# Altium schematic sheet Soft_Start.SchDoc
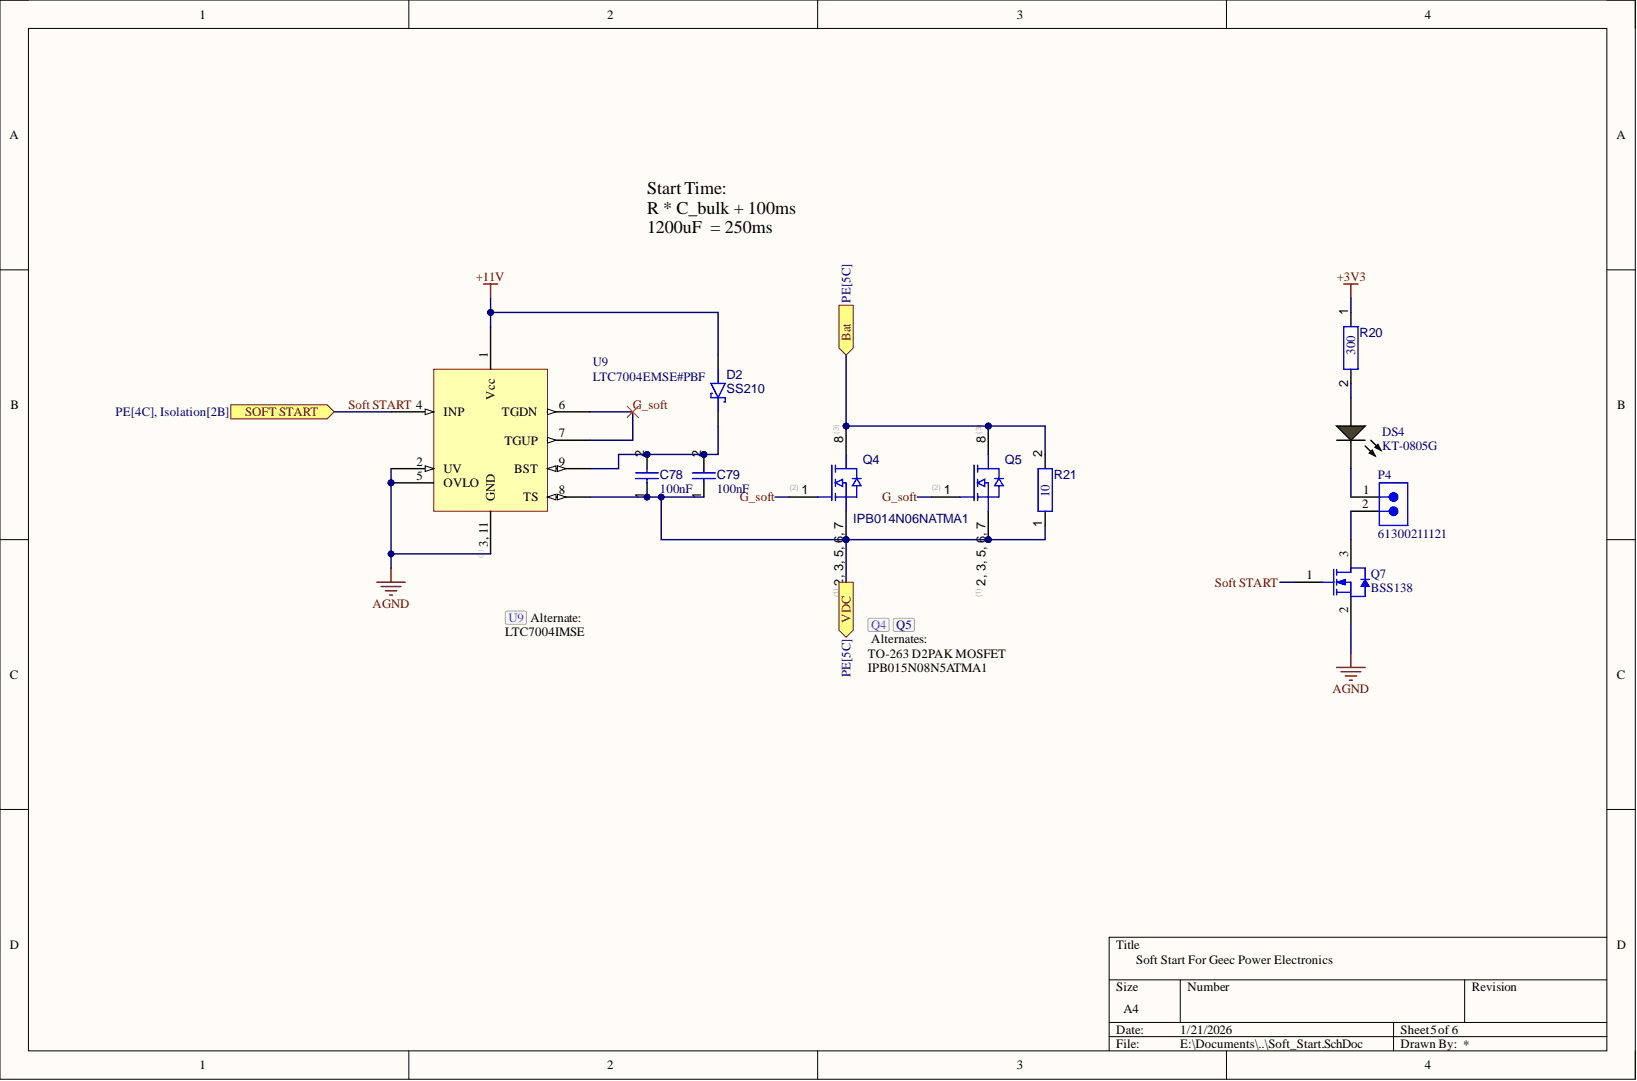
[diagram p0: Soft_Start.SchDoc]

## Parts
C78  value 100nF  [CAPC3216X06N]  (hidden comment: C1206C104K1RACTU)
C79  value 100nF  [CAPC3216X06N]  (hidden comment: C1206C104K1RACTU)
D2  SS210  [DIOM4226X24N]
DS4  KT-0805G  [WL-SMCW_0805_150080xx75000]
P4  61300211121  [61300211121]
Q4  IPB014N06NATMA1  [INF-PG-TO263-7-1_M]
Q5  IPB014N06NATMA1  [INF-PG-TO263-7-1_M]
Q7  BSS138  [FAIR-SOT23-3_V]
R20  value 300  [RESC3216X89X51NL25T25]  (hidden comment: 1206W4F3000T5E)
R21  value 10  [1623730-2-Footprint-1]  (hidden comment: 1623730-2)
U9  LTC7004EMSE#PBF  [TSSOP50P490X110_HS-11N]

## Ports
Bat, SOFT START, VDC

## Nets
+3V3: R20.1
+11V: D2.2 U9.1(Vcc)
AGND: Q7.2 U9.2(UV) U9.3(GND) U9.5(OVLO)
Bat: Q4.3 Q5.3 R21.2 ; port Bat
G_soft: Q4.2 Q5.2 U9.6(TGDN) U9.7(TGUP)
Soft START: Q7.1 U9.4(INP) ; port SOFT START
VDC: C78.1 C79.1 Q4.1 Q5.1 R21.1 U9.8(TS) ; port VDC
NetC78_2: C78.2 C79.2 D2.1 U9.9(BST)
NetDS4_1: DS4.1 R20.2
NetDS4_2: DS4.2 P4.1
NetP4_2: P4.2 Q7.3

## Library data of the parts
C78 C79 = CMP-001-00083-1: Ceramic Capacitor, Multilayer, Ceramic, 200V, 10% +Tol, 10% -Tol, X7R, 15% TC, 0.01uF, 1206; Voltage Rating: 100V; Case/Package: 1206; Component Kind: Standard; Max Operating Temperature: 125°C; Min Operating Temperature: -55°C; Mounting Technology: Surface Mount; Pins: 2; Tolerance: 10%; Library Name: University of Galway
D2 = CMP-004-00033-2: Rectifier Diode, Schottky, 1 Phase, 1 Element, 2A, 100V V(RRM), Silicon, DO-214AA; Forward Voltage: 850mV; Case/Package: SMB; Component Kind: Standard; Forward Current: 2A; JLCPN: C14996; Max Operating Temperature: 150°C; Min Operating Temperature: -65°C; Pins: 2; Library Name: University of Galway
DS4 = CMP-007-00037-1: -40℃~+85℃ 100mW 120° 2.6V~3.1V 430mcd 513nm~528nm 525nm 5mA Emerald Green 0805 LED Indication - Discrete ROHS; Case/Package: 0805; Colour: Green; Component Kind: Standard; JLCPN: C2297; Library Name: University of Galway
P4 = CMP-002-00208-1: WR-PHD Pin Header, THT, Vertical, pitch 2.54mm, 1 Row, 2P; Manufacturer: Wurth Elektronik; Contact Resistance: 20mOhm; Rated Current: 3A; Withstanding Voltage: 500V; Working Voltage: 250V; Category: Board-to-Board Connectors; Component Kind: Standard; Component Type: Standard; ComponentLink1Description: Website Link; ComponentLink1URL: https://www.we-online.com/redexpert/article/61300211121?ad; ComponentLink2Description: Datasheet Link; ComponentLink2URL: https://www.we-online.com/redexpert/spec/61300211121?ad; Design Item ID: 61300211121; Gender: Pin Header; Manufacturer Part Number: 61300211121; Match Code: WR-PHD; Mount: Through Hole; Number of Rows: 1; Operating Temperature Max: 105°C; Operating Temperature Min: -40°C; Orientation: Vertical; Packaging: Bag; Pin Count: 2; Pins: 2; Pitch: 2.54mm; Source: WR-PHD.SchLib; Library Name: University of Galway
Q4 Q5 = CMP-00000-5: Power MOSFET, N Channel, 60 V, 180 A, 0.0012 ohm, TO-263 (D2PAK), Surface Mount; Continuous Drain Current (ID): 34A; Drain to Source Breakdown Voltage: 60V; Drain to Source Resistance: 1.4mΩ; Gate to Source Voltage (Vgs): 20V; Max Power Dissipation: 214W; Case/Package: TO-263-7; Component Kind: Standard; Drain to Source Voltage (Vdss): 60V; Library Name: University of Galway
Q7 = CMP-013-00021-4: N-Channel Logic Level Enhancement Mode Field Effect Transistor, 220 mA, 50 V, -55 to 150 degC, 3-Pin SOT-23, RoHS, Tape and Reel; Manufacturer: Fairchild; Elements: 1; Input Capacitance: 27pF; Nominal Dual Supply Voltage: 50V; Nominal Vgs: 1.3V; Package Version: Rev. 12, 03/2016; Power: 360mW; Rds On Max: 3.5Ohm; Resistance: 0.7Ohm; Typical Rise Time: 9ns; Case/Package: TO-236-3; Component Kind: Standard; Datasheet URL: https://www.fairchildsemi.com/datasheets/BS/BSS138.pdf; Datasheet Version: Rev. C, 10/2005; Max Operating Temperature: 150°C; Min Operating Temperature: -55°C; Mounting Technology: SM; Package Description: 3-Pin Small Outline Transistor, Body 2.92 x 1.325 mm, Pitch 0.95 mm; Package Quantity: 1; Package Reference: SOT23-3; Packaging: TapeandReel; Pins: 3; RoHS Compliant: True; Library Name: University of Galway
R20 = CMP-009-00190-1: 250mW Thick Film Resistors 200V ±100ppm/℃ ±1% 300Ω 1206 Chip Resistor - Surface Mount ROHS; Component Kind: Standard; Library Name: University of Galway
R21 = CMP-009-00189-1: Fixed Resistor, Wire Wound, 4W, 10ohm, 6.3246V, 5% +/-Tol, 400ppm/Cel, Through Hole Mount, 3228; Voltage Rating: 6.3V; Case/Package: Axial; Component Kind: Standard; Max Operating Temperature: 275°C; Min Operating Temperature: -55°C; Mounting Technology: Axial, Through Hole; Pins: 2; Tolerance: 5%; Library Name: University of Galway
U9 = CMP-00024-00007-2: RoHS Compliant PRODUCTION (Last Updated: 2weeks ago) MSOP IC Gate Driver; Case/Package: MSOP; Component Kind: Standard; Pins: 10; Library Name: University of Galway
